# Altium schematic sheet Sheet2FullAvionics.SchDoc
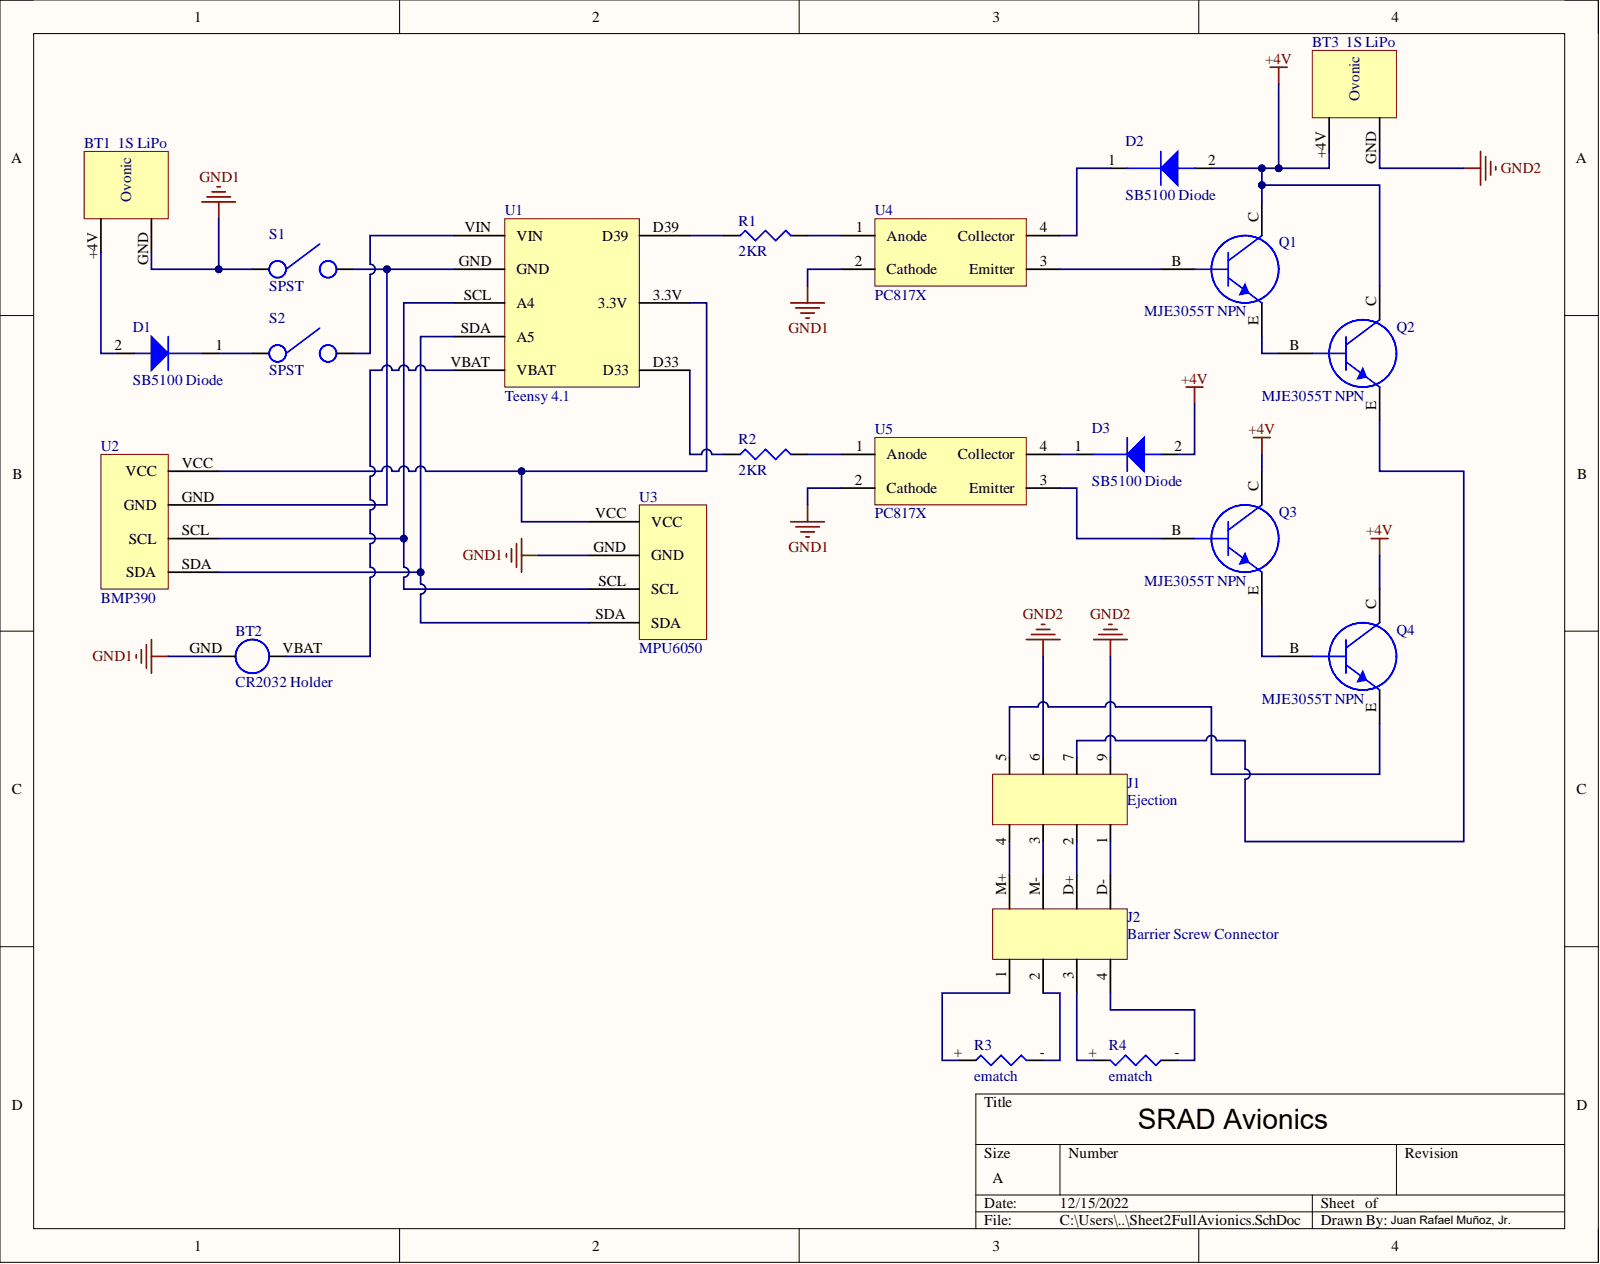
[diagram p0: Sheet2FullAvionics.SchDoc]

## Parts
BT1  1S LiPo  [1S LiPo]
BT2  CR2032 Holder  [CR2032]
BT3  1S LiPo  [1S LiPo]
D1  SB5100 Diode  Manufacturer Part Number: SB5100  [Schottky Diode SB5100]
D2  SB5100 Diode  [Schottky Diode SB5100]
D3  SB5100 Diode  Manufacturer Part Number: SB5100  [Schottky Diode SB5100]
J1  Ejection  [TERMINAL BLOCK]
J2  Barrier Screw Connector  [Barrier Block Connector]
Q1  MJE3055T NPN  Polarity: NPN  [MJE3055T]
Q2  MJE3055T NPN  Polarity: NPN  [MJE3055T]
Q3  MJE3055T NPN  Polarity: NPN  [MJE3055T]
Q4  MJE3055T NPN  Polarity: NPN  [MJE3055T]
R1  2KR  [Resistor 1206 2KR]
R2  2KR  [Resistor 1206 2KR]
R3  ematch  [eMatch]
R4  ematch  [eMatch]
S1  SPST  [SPST Switch]
S2  SPST  [SPST Switch]
U1  Teensy 4.1  [Teensy 4.1]
U2  BMP390  [BMP390]
U3  MPU6050  [MPU6050]
U4  PC817X  [PC817X  Optocoupler]
U5  PC817X  [PC817X  Optocoupler]

## Nets
+4V: BT3.+4V D2.2 D3.2 Q1.C Q2.C Q3.C Q4.C
GND1: BT1.GND BT2.GND S1.1 U3.GND U4.2 U5.2
GND2: BT3.GND J1.6 J1.9
NetBT1_+4V: BT1.+4V D1.2
NetBT2_VBAT: BT2.VBAT U1.VBAT
NetD1_1: D1.1 S2.1
NetD2_1: D2.1 U4.4
NetD3_1: D3.1 U5.4
NetJ1_1: J1.1 J2.D-
NetJ1_2: J1.2 J2.D+
NetJ1_3: J1.3 J2.M-
NetJ1_4: J1.4 J2.M+
NetJ1_5: J1.5 Q4.E
NetJ1_7: J1.7 Q2.E
NetJ2_1: J2.1 R3.+
NetJ2_2: J2.2 R3.-
NetJ2_3: J2.3 R4.+
NetJ2_4: J2.4 R4.-
NetQ1_B: Q1.B U4.3
NetQ1_E: Q1.E Q2.B
NetQ3_B: Q3.B U5.3
NetQ3_E: Q3.E Q4.B
NetR1_1: R1.1 U1.D39
NetR1_2: R1.2 U4.1
NetR2_1: R2.1 U1.D33
NetR2_2: R2.2 U5.1
NetS1_2: S1.2 U1.GND U2.GND
NetS2_2: S2.2 U1.VIN
NetU1_3.3V: U1.3.3V U2.VCC U3.VCC
NetU1_SCL: U1.SCL U2.SCL U3.SCL
NetU1_SDA: U1.SDA U2.SDA U3.SDA

## Library data of the parts
BT1 BT3 = 1S LiPo: 1S 3.7-4.2V Lithium Polymer battery from Ovonic with 750mAh and 30C; Component Kind: Standard; Library Name: Schlib1.SchLib
BT2 = CR2032: BATT HOLDER CR2032 1 CELL PCB; Component Kind: Standard; Library Name: Schlib1.SchLib
D1-D3 = Schottky Diode SB5100: DIODE SCHOTTKY 100V 5A DO201AD; Manufacturer: ON Semiconductor / Fairchild; Element Configuration: Single; Forward Voltage: 850mV; Max Forward Surge Current (Ifsm): 100A; Max Reverse Current: 500uA; Max Surge Current: 150A; Polarity: Standard; Average Rectified Current: 5A; Case/Package: DO-201AD; Component Kind: Standard; ComponentLink1Description: https://datasheet.ciiva.com/911/sb5100-911093.pdf?src-supplier=Avnet; ComponentLink1URL: https://datasheet.ciiva.com/911/sb5100-911093.pdf?src-supplier=Avnet; ComponentLink2Description: https://datasheet.ciiva.com/4714/sb5100-191746-4714390.pdf?src-supplier=Mouser; ComponentLink2URL: https://datasheet.ciiva.com/4714/sb5100-191746-4714390.pdf?src-supplier=Mouser; ComponentLink3Description: https://datasheet.ciiva.com/7965/78621-7965207.pdf?src-supplier=Element14; ComponentLink3URL: https://datasheet.ciiva.com/7965/78621-7965207.pdf?src-supplier=Element14; ComponentLink4Description: https://datasheet.ciiva.com/911/sb5100-911279.pdf?src-supplier=Digi-Key; ComponentLink4URL: https://datasheet.ciiva.com/911/sb5100-911279.pdf?src-supplier=Digi-Key; ComponentLink5Description: https://datasheet.ciiva.com/911/sb5100-911278.pdf?src-supplier=Digi-Key; ComponentLink5URL: https://datasheet.ciiva.com/911/sb5100-911278.pdf?src-supplier=Digi-Key; Current Rating: 5A; Diameter: 5.6mm; Forward Current: 5A; Height: 9.5mm; Lead Free: Lead Free; Length: 0.25inch; Library Name: Schlib1.SchLib; Max Operating Temperature: 150°C; Max Repetitive Reverse Voltage (Vrrm): 100V; Max Reverse Leakage Current: 500uA; Max Reverse Voltage (DC): 100V; Min Operating Temperature: -50°C; Mount: Through Hole; Number of Pins: 2; Output Current: 5A; Package Quantity: 1; Packaging: Tape and Reel; Peak Non-Repetitive Surge Current: 150A; Pricing 1: 1=1.21000 (USD); Radiation Hardening: No; Reach SVHC Compliant: Yes; RoHS: Yes; RoHS Compliant: Yes; Series: -; Stock 1: 1; Supplier 1: Digi-Key; Supplier Part Number 1: SB5100FSCT-ND; Voltage Rating (DC): 100V; Weight: 0.36g; Width: 0.25inch
  D2: Manufacturer Part Number: SB5100
J1 = TERMINAL BLOCK: 4 Position Wire to Board Terminal Block Horizontal with Board 0.100" (2.54mm) Through Hole 6A 125V 20-30 AWG; Manufacturer: Phoenix Contact; Manufacturer Part Number: 1725672; Approvals: UL,CSA; Connector Type: Screw,PCB,Terminal Block; Max Supply Voltage: 63V; Number of Circuits: 4; Number of Levels: 1; Wire Gauge (Max): 26AWG; Wire Gauge (Min): 20AWG; Category: Terminal Blocks; Color: Green; Component Kind: Standard; ComponentLink1Description: https://datasheet.ciiva.com/16071/1725672-16071850.pdf?src-supplier=Onlinecomponents.com; ComponentLink1URL: https://datasheet.ciiva.com/16071/1725672-16071850.pdf?src-supplier=Onlinecomponents.com; ComponentLink2Description: https://datasheet.ciiva.com/15169/getdatasheetpartid-177917-15169671.pdf?src-supplier=Verical; ComponentLink2URL: https://datasheet.ciiva.com/15169/getdatasheetpartid-177917-15169671.pdf?src-supplier=Verical; ComponentLink3Description: https://datasheet.ciiva.com/823/1725672-823812.pdf?src-supplier=Digi-Key; ComponentLink3URL: https://datasheet.ciiva.com/823/1725672-823812.pdf?src-supplier=Digi-Key; ComponentLink4Description: https://datasheet.ciiva.com/11757/0900766b80363fc3-11757428.pdf?src-supplier=RSComponents; ComponentLink4URL: https://datasheet.ciiva.com/11757/0900766b80363fc3-11757428.pdf?src-supplier=RSComponents; ComponentLink5Description: https://datasheet.ciiva.com/1120/5117-1120512.pdf?src-supplier=Farnell; ComponentLink5URL: https://datasheet.ciiva.com/1120/5117-1120512.pdf?src-supplier=Farnell; ComponentLink6Description: https://datasheet.ciiva.com/7053/1697274-7053094.pdf?src-supplier=Newark; ComponentLink6URL: https://datasheet.ciiva.com/7053/1697274-7053094.pdf?src-supplier=Newark; ComponentLink7Description: https://datasheet.ciiva.com/1118/5117-1118545.pdf?src-supplier=Element14; ComponentLink7URL: https://datasheet.ciiva.com/1118/5117-1118545.pdf?src-supplier=Element14; Contact Material: Tin; Current: 6A; Current Rating: 6A; Depth: 6.2mm; Flammability Rating: UL94 V-0; Height: 10.62mm; Housing Color: Green; Housing Material: Polyamide; Insulation Material: Nylon; Lead Free: Lead Free; Length: 6.2mm; Library Name: Schlib1.SchLib; Material: Nylon; Max Current Rating: 6A; Mount: Through Hole; Number of Contacts: 4; Number of Pins: 4; Number of Poles: 4; Number of Positions: 4; Number of Positions Per Level: 4; Number of Rows: 1; Number of Ways: 4; Orientation: Straight; Package Quantity: 50; Packaging: Bulk; Pitch: 2.54mm; Pricing 1: 1=3.97000, 10=3.49600, 50=3.34400, 100=3.25280, 250=3.04000, 500=2.79682, 1000=2.58399, 2500=2.46240 (USD); Radiation Hardening: No; Reach SVHC Compliant: Yes; RoHS: Yes; RoHS Compliant: Yes; Series: MPT; Stock 1: 5430; Supplier 1: Digi-Key; Supplier Part Number 1: 277-1275-ND; Termination: Solder; Voltage: 125V; Voltage Rating: 125V; Weight: 0.044798oz; Width: 14mm; Wire/Cable Gauge: 20AWG
J2 = Barrier Block Connector: 4 Circuit 0.437" (11.10mm) Barrier Block Connector Screws 25A 300V 14-22 AWG; Manufacturer: TE Connectivity; Manufacturer Part Number: 1546670-4; Approvals: UL; Mounting Ears: Yes (With); Mounting Insert: No (Without); Number of Circuits: 4; Number of Contacts: 8; Number of Levels: 1; Pitch: 11.1mm; Thread Size: #6-32; Wire Gauge (Max): 22AWG; Category: Terminal Blocks; Color: Black; Component Kind: Standard; ComponentLink1Description: https://datasheet.ciiva.com/30492/0900766b8122207d-30492418.pdf?src-supplier=RSComponents; ComponentLink1URL: https://datasheet.ciiva.com/30492/0900766b8122207d-30492418.pdf?src-supplier=RSComponents; ComponentLink2Description: https://datasheet.ciiva.com/776/eng-cd-1546670-k18-776752.pdf?src-supplier=Digi-Key; ComponentLink2URL: https://datasheet.ciiva.com/776/eng-cd-1546670-k18-776752.pdf?src-supplier=Digi-Key; ComponentLink3Description: https://datasheet.ciiva.com/3141/eng-cs-1308389-barrier-strips-0607-3141462.pdf?src-supplier=Avnet; ComponentLink3URL: https://datasheet.ciiva.com/3141/eng-cs-1308389-barrier-strips-0607-3141462.pdf?src-supplier=Avnet; ComponentLink4Description: https://datasheet.ciiva.com/30215/eng-cs-1308389-barrier-strips-0607-30215653.pdf?src-supplier=Onlinecomponents.com; ComponentLink4URL: https://datasheet.ciiva.com/30215/eng-cs-1308389-barrier-strips-0607-30215653.pdf?src-supplier=Onlinecomponents.com; ComponentLink5Description: https://datasheet.ciiva.com/15169/getdatasheetpartid-154556-15169541.pdf?src-supplier=Verical; ComponentLink5URL: https://datasheet.ciiva.com/15169/getdatasheetpartid-154556-15169541.pdf?src-supplier=Verical; ComponentLink6Description: https://datasheet.ciiva.com/16794/70088626-16794325.pdf?src-supplier=Allied+Electronics; ComponentLink6URL: https://datasheet.ciiva.com/16794/70088626-16794325.pdf?src-supplier=Allied+Electronics; Contact Material: Brass; Contact Plating: Tin; Current Rating: 25A; Depth: 27.94mm; ELV: Compliant; Flammability Rating: UL94 V-0; Housing Color: Black; Housing Material: Thermoplastic; Insulation Material: Thermoplastic; Lead Free: Lead Free; Length: 2.496inch; Library Name: Schlib1.SchLib; Material: Thermoplastic; Max Current Rating: 25A; Max Operating Temperature: 248°C; Max Supply Voltage (AC): 300V; Max Voltage Rating (AC): 300V; Min Operating Temperature: -40°C; Mount: Panel,Chassis; Number of Poles: 4; Number of Positions: 4; Number of Rows: 2; Number of Wire Entries: 8; Orientation: Straight; Package Quantity: 100; Packaging: Carton; Pricing 1: 1=2.65000, 10=2.00300, 25=1.85680, 50=1.66940, 100=1.62860, 250=1.42508, 500=1.30292, 1000=1.18077, 5000=1.03826 (USD); Radiation Hardening: No; RoHS: Yes; RoHS Compliant: Yes; Series: Buchanan; Stock 1: 760; Supplier 1: Digi-Key; Supplier Part Number 1: A98516-ND; Terminal Finish: Nickel; Terminal Material: Brass; Termination: Screw; Voltage: 300V; Voltage Rating: 300V; Wire Gauge (Min): 22AWG; Wire/Cable Gauge: 22AWG
Q1-Q4 = MJE3055T: Bipolar (BJT) Transistor NPN 60 V 10 A 2MHz 75 W Through Hole TO-220; Manufacturer: ON Semiconductor / Fairchild; Collector Base Voltage (VCBO): 70V; Collector Emitter Breakdown Voltage: 60V; Collector Emitter Saturation Voltage: 1.1V; Element Configuration: Single; Emitter Base Voltage (VEBO): 5V; hFE Min: 20; Max Breakdown Voltage: 80V; Max Collector Current: 10A; Max Power Dissipation: 75W; Case/Package: TO-220-3; Category: BJTs; Collector Emitter Voltage (VCEO): 60V; Component Kind: Standard; ComponentLink1Description: https://datasheet.ciiva.com/30498/161233-da-01-en-transistor-mje-3055-t-30498421.pdf?src-supplier=Conrad; ComponentLink1URL: https://datasheet.ciiva.com/30498/161233-da-01-en-transistor-mje-3055-t-30498421.pdf?src-supplier=Conrad; Gain Bandwidth Product: 2MHz; Height: 9.15mm; Length: 10.28mm; Library Name: Schlib1.SchLib; Manufacturer Part Number: MJE3055T; Max Frequency: 2MHz; Max Operating Temperature: 150°C; Min Operating Temperature: -55°C; Mount: Through Hole; Number of Pins: 3; Package Quantity: 50; Packaging: Rail/Tube; RoHS: No; Supplier 1: Digi-Key; Supplier Part Number 1: MJE3055TOS-ND; Transition Frequency: 2MHz; Width: 4.6mm
R1 R2 = Resistor 1206 2KR: 2 kOhms ±5% 0.25W, 1/4W Chip Resistor 1206 (3216 Metric) Moisture Resistant Thick Film; Manufacturer: Yageo; Manufacturer Part Number: RC1206JR-072KL; Max Power Dissipation: 250mW; Temperature Coefficient: 100ppm/°C; Voltage Rating: 200V; Case Code (Imperial): 1206; Case Code (Metric): 3216; Case/Package: 1206; Category: Chip SMD Resistors; Component Kind: Standard; ComponentLink1Description: https://datasheet.ciiva.com/16741/pyu-rc1206-51-rohs-l-4-16741159.pdf?src-supplier=Future+Electronics; ComponentLink1URL: https://datasheet.ciiva.com/16741/pyu-rc1206-51-rohs-l-4-16741159.pdf?src-supplier=Future+Electronics; Construction: Rectangular; Contact Plating: Tin,Matte; Depth: 1.6mm; Features: Moisture Resistant; Lead Free: Lead Free; Length: 3.1mm; Library Name: Schlib1.SchLib; Max Operating Temperature: 155°C; Military Standard: Not; Min Operating Temperature: -55°C; Number of Terminations: 2; Packaging: Tape and Reel; Power Rating: 250mW; Pricing 1: 1=0.10000, 10=0.05600, 100=0.02260, 1000=0.01017, 2500=0.00883 (USD); Radiation Hardening: No; Resistance: 2kR; Resistor Type: Thick Film; RoHS: Yes; RoHS Compliant: Yes; Series: RC; Stock 1: 254959; Supplier 1: Digi-Key; Supplier Part Number 1: 311-2.0KERCT-ND; Technology: THICK FILM; Termination: SMD/SMT; Tolerance: 5%; Voltage Rating (DC): 200V; Working Voltage: 200V
R3 R4 = eMatch: An eMatch used for ejection charges. 0.1R 0.5-1.0A; Component Kind: Standard; Library Name: Schlib1.SchLib
S1 S2 = SPST Switch: Product Name : Push Button Switch;Model : DS228;Action Type : Latching  Contact configuration : SPST;Rating Voltage : AC 120V 3AAC 250V 1.5A;Terminals Quantity : 2  Mounting Hole Diameter : 12mm / 0.47";Button Diameter : 9mm/ 0.35";Overall Size : 16 x 22mm/ 0.63" x 0.87"(D*H)  Material : Plastic & Metal;Color : Red, Black  Net Weight : 31g;Package Content : 10 x Push Button Switch; Component Kind: Standard; Library Name: Schlib1.SchLib
U1 = Teensy 4.1: Teensy USB Board, Version 4.1, With Ethernet; Component Kind: Standard; Library Name: Schlib1.SchLib
U2 = BMP390: Precision Barometric Pressure and Altimeter with Stemma QT Connectors for I2C or SPI protocols compatible with Arduino; Component Kind: Standard; Library Name: Schlib1.SchLib
U3 = MPU6050: HiLetgo GY-521 MPU-6050 6 DOF Accelerometer Gyroscope Sensor Module 16 Bit AD Converter I2C for Arduino; Component Kind: Standard; Library Name: Schlib1.SchLib
U4 U5 = PC817X  Optocoupler: Optoisolator Transistor Output 5000Vrms 1 Channel 4-DIP; Manufacturer: Sharp; Approvals: UL; Collector Emitter Breakdown Voltage: 35V; Collector Emitter Saturation Voltage: 200mV; Collector Emitter Voltage (VCEO): 80V; Current Transfer Ratio: 600%; Fall Time: 18000ns; Forward Current: 50mA; Forward Voltage: 1.4V; Input Type: DC; Max Power Dissipation: 200mW; Number of Channels: 1; Reverse Breakdown Voltage: 6V; Case/Package: PDIP; Category: Optocouplers; Collector-emitter Voltage-Max: 200mV; Component Kind: Standard; ComponentLink1Description: https://datasheet.ciiva.com/2092/pc817x20series-2092530.pdf?src-supplier=Digi-Key; ComponentLink1URL: https://datasheet.ciiva.com/2092/pc817x20series-2092530.pdf?src-supplier=Digi-Key; ComponentLink2Description: https://datasheet.ciiva.com/8098/pc817x-e-8098845.pdf?src-supplier=Avnet; ComponentLink2URL: https://datasheet.ciiva.com/8098/pc817x-e-8098845.pdf?src-supplier=Avnet; Lead Free: Contains Lead; Library Name: Schlib1.SchLib; Manufacturer Part Number: PC817X; Max Breakdown Voltage: 35V; Max Collector Current: 50mA; Max Input Current: 50mA; Max Operating Temperature: 100°C; Min Operating Temperature: -30°C; Mount: Through Hole; Number of Circuits: 1; Number of Elements: 1; Number of Pins: 4; Output Current per Channel: 50mA; Output Voltage: 80V; Package Quantity: 50; Packaging: Rail/Tube; Power Dissipation: 200mW; Radiation Hardening: No; Reach SVHC Compliant: Yes; Rise Time: 18000ns; RoHS: No; RoHS Compliant: No; Supplier 1: Digi-Key; Supplier Part Number 1: 425-1464-5-ND
